# Altium schematic sheet Drive_DC_Motor.SchDoc(Drive_DC_Motor)
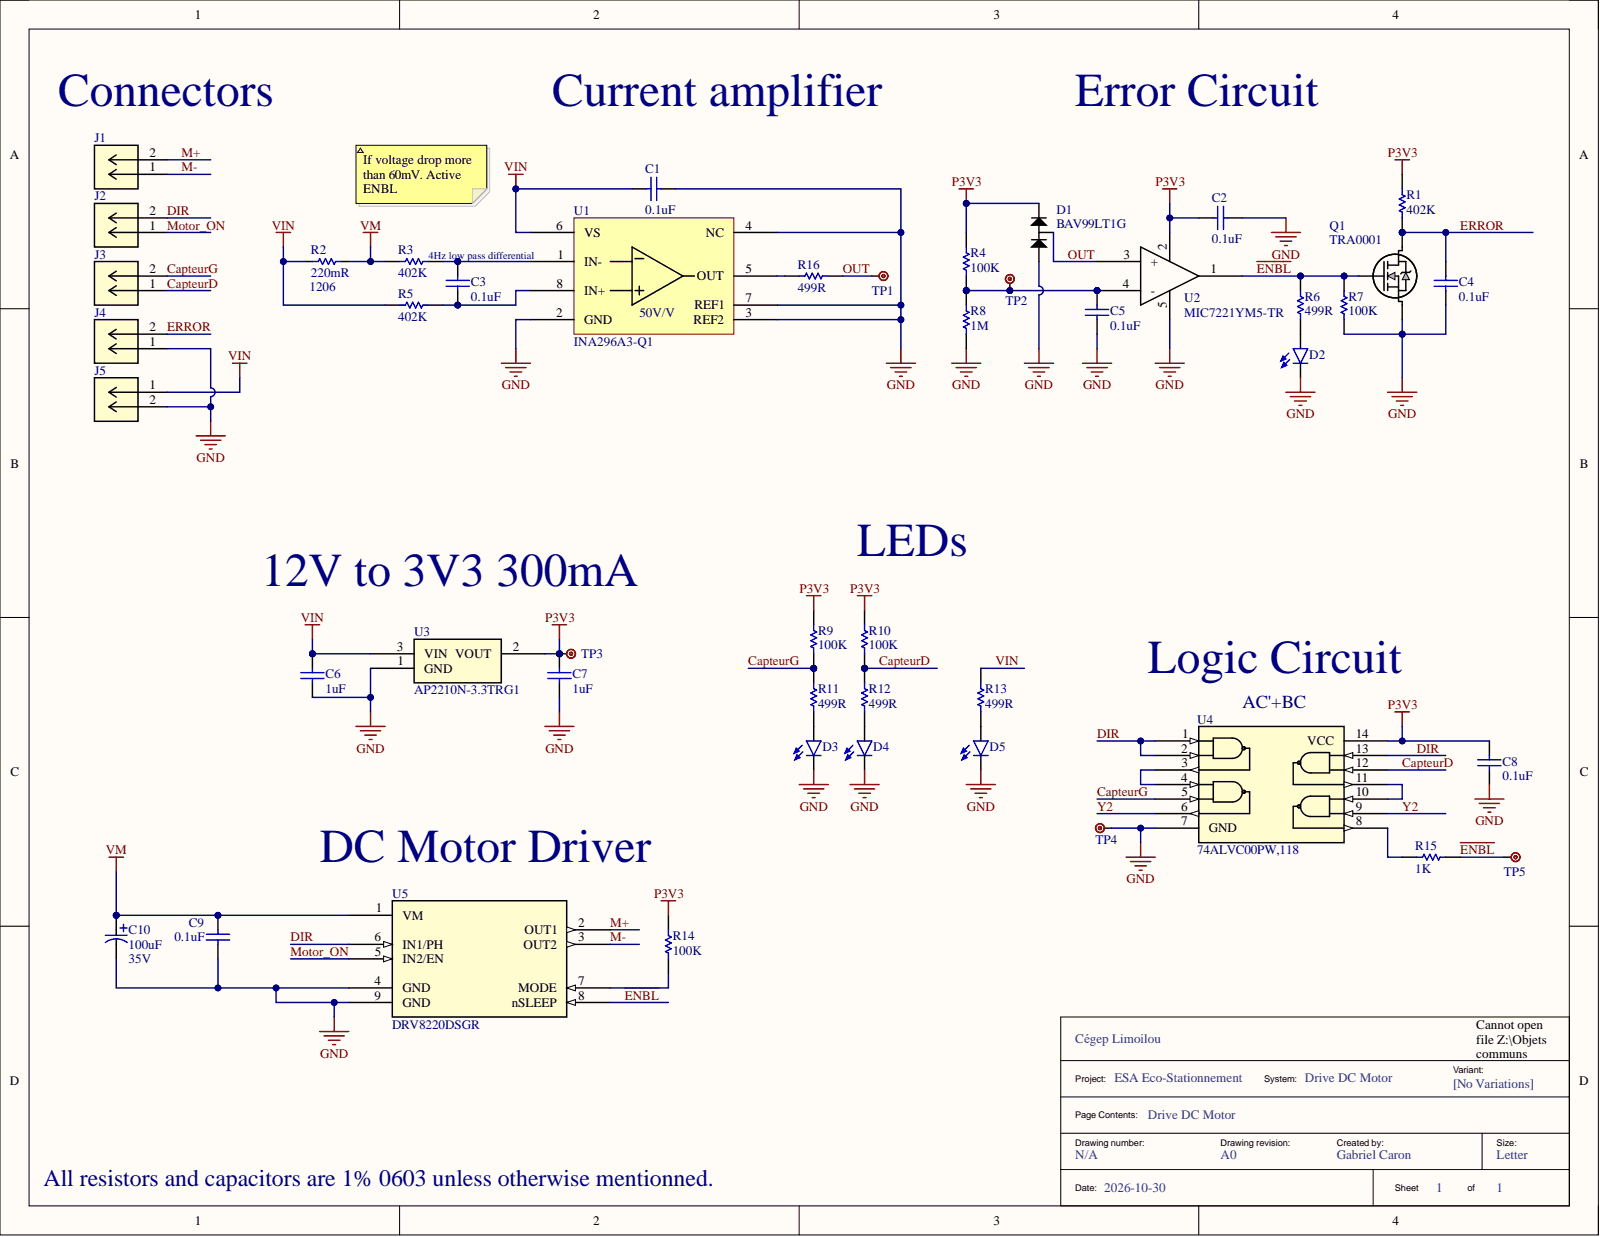
[diagram p0: Drive_DC_Motor.SchDoc]

## Parts
C1  value 0.1uF  [C0603-P]  (hidden comment: 06035C104KAT2A)
C2  value 0.1uF  [C0603-P]  (hidden comment: 06035C104KAT2A)
C3  value 0.1uF  [C0603-P]  (hidden comment: 06035C104KAT2A)
C4  value 0.1uF  [C0603-P]  (hidden comment: 06035C104KAT2A)
C5  value 0.1uF  [C0603-P]  (hidden comment: 06035C104KAT2A)
C6  value 1uF  [C0805]  (hidden comment: CC0805KKX7R9BB105)
C7  value 1uF  [C0805]  (hidden comment: CC0805KKX7R9BB105)
C8  value 0.1uF  [C0603-P]  (hidden comment: 06035C104KAT2A)
C9  value 0.1uF  [C0603-P]  (hidden comment: 06035C104KAT2A)
C10  value 100uF  Voltage: 35V  [WCAP-ASLI_6.3X7.7(DXL)]  (hidden comment: 865080545012)
D1  BAV99LT1G  [BAV99LT1G]
D2  LTST-C190KRKT  [LEDC1608X35N]  (value not drawn)
D3  LTST-C190KRKT  [LEDC1608X35N]  (value not drawn)
D4  LTST-C190KRKT  [LEDC1608X35N]  (value not drawn)
D5  MDIO0070  [LEDC1608X35N]  (value not drawn)
J1  VN02A1500000G  [CONN2_VN02A1500000G_AMP]  (value not drawn)
J2  VN02A1500000G  [CONN2_VN02A1500000G_AMP]  (value not drawn)
J3  VN02A1500000G  [CONN2_VN02A1500000G_AMP]  (value not drawn)
J4  VN02A1500000G  [CONN2_VN02A1500000G_AMP]  (value not drawn)
J5  VN02A1500000G  [CONN2_VN02A1500000G_AMP]  (value not drawn)
Q1  TRA0001  [TO-236AB_NEX]
R1  value 402K  [R0603]  (hidden comment: RC0603FR-07402KL)
R2  value 220mR  Package: 1206  [R1206]  (hidden comment: RL1206FR-070R22L)
R3  value 402K  [R0603]  (hidden comment: RC0603FR-07402KL)
R4  value 100K  [R0603]  (hidden comment: RC0603FR-07100KL)
R5  value 402K  [R0603]  (hidden comment: RC0603FR-07402KL)
R6  value 499R  [R0603]  (hidden comment: RT0603BRD07499RL)
R7  value 100K  [R0603]  (hidden comment: RC0603FR-07100KL)
R8  value 1M  [R0603]  (hidden comment: RC0603FR-071ML)
R9  value 100K  [R0603]  (hidden comment: RC0603FR-07100KL)
R10  value 100K  [R0603]  (hidden comment: RC0603FR-07100KL)
R11  value 499R  [R0603]  (hidden comment: RT0603BRD07499RL)
R12  value 499R  [R0603]  (hidden comment: RT0603BRD07499RL)
R13  value 499R  [R0603]  (hidden comment: RT0603BRD07499RL)
R14  value 100K  [R0603]  (hidden comment: RC0603FR-07100KL)
R15  value 1K  [R0603]  (hidden comment: RC0603FR-071KL)
R16  value 499R  [R0603]  (hidden comment: RT0603BRD07499RL)
TP1  5000  [TP_5000_MIN]  (value not drawn)
TP2  5000  [TP_5000_MIN]  (value not drawn)
TP3  5000  [TP_5000_MIN]  (value not drawn)
TP4  5000  [TP_5000_MIN]  (value not drawn)
TP5  5000  [TP_5000_MIN]  (value not drawn)
U1  INA296A3-Q1  [SOIC127P600X175-8N]
U2  MIC7221YM5-TR  [MIC7221YM5-TR]
U3  AP2210N-3.3TRG1  [SOT_3.3TRG1_DIO-L]
U4  74ALVC00PW,118  [TSSOP14_SOT402-1_NEX]
U5  DRV8220DSGR  [WSON8_DSG_TEX]

## Nets
GND: C1.2 C2.2 C4.1 C5.1 C6.1 C7.1 C8.1 C9.1 C10.2 D1.1 D2.C D3.C D4.C D5.C J4.1 J5.2 Q1.2 R7.1 R8.1 TP4.1 U1.2(GND) U1.3(REF2) U1.4(NC) U1.7(REF1) U2.5 U3.1 U4.7(GND) U5.4(GND) U5.9(GND)
P3V3: C2.1 C7.2 C8.2 D1.2 R1.2 R4.2 R9.2 R10.2 R14.2 TP3.1 U2.2 U3.2 U4.14(VCC)
VM: C9.2 C10.1 R2.2 R3.1 U5.1(VM)
CapteurD: J3.1 R10.1 R12.2 U4.12
CapteurG: J3.2 R9.1 R11.2 U4.5
DIR: J2.2 U4.1 U4.2 U4.13 U5.6(IN1/PH)
~{ENBL}: Q1.1 R6.2 R7.2 R15.2 TP5.1 U2.1 U5.8(nSLEEP)
ERROR: C4.2 J4.2 Q1.3 R1.1
M+: J1.2 U5.2(OUT1)
M-: J1.1 U5.3(OUT2)
Motor_ON: J2.1 U5.5(IN2/EN)
OUT: D1.3 R16.2 TP1.1 U2.3(+)
VIN: C1.1 C6.2 J5.1 R2.1 R5.1 R13.2 U1.6(VS) U3.3
Y2: U4.6 U4.9
NetC3_1: C3.1 R5.2 U1.8(IN+)
NetC3_2: C3.2 R3.2 U1.1(IN-)
NetC5_2: C5.2 R4.1 R8.2 TP2.1 U2.4(-)
NetD2_A: D2.A R6.1
NetD3_A: D3.A R11.1
NetD4_A: D4.A R12.1
NetD5_A: D5.A R13.1
NetR14_1: R14.1 U5.7(MODE)
NetR15_1: R15.1 U4.8
NetR16_1: R16.1 U1.5(OUT)
NetU4_3: U4.3 U4.4
NetU4_10: U4.10 U4.11

## Library data of the parts
C1-C5 C8 C9 = CAP0021: CAP CER 0.1UF 50V X7R 0603 10%; Manufacturer: KYOCERA AVX; ID_CEP: MCAP0021; Verif_1: RCA le 2022-02-21; Component Kind: Standard; Dielectric: X7R; Fitted: Fitted; Package: 0603; PN_Manuf: 06035C104KAT2A; Library Name: Eco-Stationnement.SchLib
C6 C7 = CAP0002: CAP CER 1UF 50V X7R 0805; Manufacturer: Yageo; ID_CEP: MCAP0002; Verif_1: JSMG; Component Kind: Standard; Cost: 0.08; Fitted: Fitted; LatestRevisionDate: 17-Jul-2002; LatestRevisionNote: Re-released for DXP Platform.; Package: 0805; PackageDescription: Radial Cap, Thru-Hole; 2 Leads; 0.3 in Pin Spacing; PN_Manuf: CC0805KKX7R9BB105; Published: 8-Jun-2000; Library Name: Eco-Stationnement.SchLib; Publisher: Altium Limited
C10 = 865080545012: CAP ALUM 100UF 20% 35V SMD; Manufacturer: Würth Elektronik; Component Kind: Standard; Fitted: Fitted; Package: 0603; PN_Manuf: 865080545012; Library Name: Eco-Stationnement.SchLib
D1 = DIO0002: Diode Array 1 Pair Series Connection Standard 100V 215mA (DC) SOT-23-3; Manufacturer: ON Semiconductor; ID_CEP: MDIO0002; Verif_1: JSMG; Component Kind: Standard; Cost: 0.18; Fitted: Fitted; Package: 3-SOT-23; PN_Manuf: BAV99LT1G; Library Name: Eco-Stationnement.SchLib
D2-D4 = LTST-C190KRKT: LED RED CLEAR CHIP SMD; Manufacturer: Lite-On Inc.; Availability: In Stock; Check_prices: https://www.snapeda.com/parts/LTST-C190KRKT/Lite-On+Inc./view-part/?ref=eda; Component Kind: Standard; ComponentLink1Description: New link; Fitted: Fitted; MF: Lite-On Inc.; MP: LTST-C190KRKT; Package: 0603 Lite-On; PN_Manuf: LTST-C190KRKT; Price: None; Purchase-URL: https://pricing.snapeda.com/search/part/LTST-C190KRKT/?ref=eda; SnapEDA_Link: https://www.snapeda.com/parts/LTST-C190KRKT/Lite-On+Inc./view-part/?ref=snap; Library Name: Eco-Stationnement.SchLib
D5 = MDIO0070: LED GREEN CLEAR SMD; Manufacturer: Lite-On Inc.; MP: LTST-C191KGKT; Availability: In Stock; Check_prices: https://www.snapeda.com/parts/LTST-C190KRKT/Lite-On+Inc./view-part/?ref=eda; Component Kind: Standard; ComponentLink1Description: New link; Fitted: Fitted; ID_CEP: DIO0070; MF: Lite-On Inc.; Package: 0603 Lite-On; PN_Manuf: LTST-C191KGKT; Price: None; Purchase-URL: https://pricing.snapeda.com/search/part/LTST-C190KRKT/?ref=eda; SnapEDA_Link: https://www.snapeda.com/parts/LTST-C190KRKT/Lite-On+Inc./view-part/?ref=snap; Library Name: Eco-Stationnement.SchLib
J1-J5 = VN02A1500000G: TERM BLK 2P SIDE ENT 2.54MM PCB; Manufacturer: Amphenol ICC; Component Kind: Standard; Fitted: Fitted; Manufacturer_Name: Amphenol ICC; Manufacturer_Part_Number: VN02A1500000G; PN_Manuf: VN02A1500000G; RefDes: RefDes; Type: Type; Copyright: Copyright (C) 2025 Ultra Librarian. All rights reserved.; Library Name: Eco-Stationnement.SchLib
Q1 = TRA0001: MOSFET N-CH 60V 300MA TO236AB; Manufacturer: Nexperia; Manufacturer_Part_Number: 2N7002,215; Verif_1: GC; Component Kind: Standard; Fitted: Fitted; ID_CEP: TRA0001; Manufacturer_Name: Nexperia; PN_Manuf: 2N7002,215; Copyright: Copyright (C) 2025 Ultra Librarian. All rights reserved.; Library Name: Eco-Stationnement.SchLib
R1 R3 R5 = RC0603FR-07402KL: RES 402K OHM 1% 1/10W 0603; Manufacturer: YAGEO; Verif_1: JSMG; Component Kind: Standard; Cost: 0.01; Fitted: Fitted; LatestRevisionDate: 17-Jul-2002; LatestRevisionNote: Re-released for DXP Platform.; Package: 0603; PackageDescription: Axial Device, Thru-Hole; 2 Leads; 0.3 in Pin Spacing; PackageReference: AXIAL-0.3; PN_Manuf: RC0603FR-07402KL; Published: 8-Jun-2000; Library Name: Eco-Stationnement.SchLib; Publisher: Altium Limited
R2 = RL1206FR-070R22L: RES 0.22 OHM 1% 1/4W 1206; Manufacturer: YAGEO; Verif_1: GC; Component Kind: Standard; Cost: 0.01; Fitted: Fitted; LatestRevisionDate: 17-Jul-2002; LatestRevisionNote: Re-released for DXP Platform.; PackageDescription: Axial Device, Thru-Hole; 2 Leads; 0.3 in Pin Spacing; PackageReference: AXIAL-0.3; PN_Manuf: RL1206FR-070R22L; Published: 8-Jun-2000; Library Name: Eco-Stationnement.SchLib; Publisher: Altium Limited
R4 R7 R9 R10 R14 = RES0003: RES SMD 100K OHM 1% 1/10W 0603; Manufacturer: YAGEO; ID_CEP: MRES0003; Verif_1: JSMG; Component Kind: Standard; Cost: 0.01; Fitted: Fitted; LatestRevisionDate: 17-Jul-2002; LatestRevisionNote: Re-released for DXP Platform.; Package: 0603; PackageDescription: Axial Device, Thru-Hole; 2 Leads; 0.3 in Pin Spacing; PackageReference: AXIAL-0.3; PN_Manuf: RC0603FR-07100KL; Published: 8-Jun-2000; Library Name: Eco-Stationnement.SchLib; Publisher: Altium Limited
R6 R11-R13 R16 = RES0015: RES SMD 499 OHM 0.1% 1/10W 0603; Manufacturer: YAGEO; ID_CEP: MRES0015; Verif_1: JSMG; Component Kind: Standard; Cost: X; Fitted: Fitted; LatestRevisionDate: 17-Jul-2002; LatestRevisionNote: Re-released for DXP Platform.; Package: 0603; PackageDescription: Axial Device, Thru-Hole; 2 Leads; 0.3 in Pin Spacing; PackageReference: AXIAL-0.3; PN_Manuf: RT0603BRD07499RL; Published: 8-Jun-2000; Library Name: Eco-Stationnement.SchLib; Publisher: Altium Limited
R8 = RES0023: RES SMD 1M OHM 1% 1/10W 0603; Manufacturer: Yageo; ID_CEP: MRES0023; Verif_1: JSMG; Component Kind: Standard; Cost: 0.01; Fitted: Fitted; LatestRevisionDate: 17-Jul-2002; LatestRevisionNote: Re-released for DXP Platform.; Package: 0603; PackageDescription: Axial Device, Thru-Hole; 2 Leads; 0.3 in Pin Spacing; PackageReference: AXIAL-0.3; PN_Manuf: RC0603FR-071ML; Published: 8-Jun-2000; Library Name: Eco-Stationnement.SchLib; Publisher: Altium Limited
R15 = RES0001: RES SMD 1K OHM 1% 1/10W 0603; Manufacturer: Yageo; ID_CEP: MRES0001; Verif_1: JSMG; Component Kind: Standard; Cost: 0.01; Fitted: Fitted; LatestRevisionDate: 17-Jul-2002; LatestRevisionNote: Re-released for DXP Platform.; Package: 0603; PackageDescription: Axial Device, Thru-Hole; 2 Leads; 0.3 in Pin Spacing; PackageReference: AXIAL-0.3; PN_Manuf: RC0603FR-071KL; Published: 8-Jun-2000; Library Name: Eco-Stationnement.SchLib; Publisher: Altium Limited
TP1-TP5 = DIV0034: PC TEST POINT MINIATURE RED 1mm; Manufacturer: Keystone Electronics; Fitted: Not Fitted; ID_CEP: MDIV0034; Verif_1: RCA le 2024-03-27; Component Kind: Standard; PN_Manuf: 5000; Library Name: Eco-Stationnement.SchLib
U1 = INA296A3-Q1: Current Sense Amplifier 1 Circuit Single-Ended 8-SOIC; Manufacturer: Texas Instruments; Component Kind: Standard; Fitted: Fitted; PN_Manuf: INA296A3-Q1; Library Name: Eco-Stationnement.SchLib
U2 = MIC7221YM5-TR: IC COMPARATOR 1 GEN PUR SOT23-5; Manufacturer: Microchip; Component Kind: Standard; Fitted: Fitted; PN_Manuf: MIC7221YM5-TR; Library Name: Eco-Stationnement.SchLib
U3 = AP2210N-3.3TRG1: IC REG LINEAR 3.3V 300MA SOT23-3; Manufacturer: Diodes Inc; Component Kind: Standard; Fitted: Fitted; Manufacturer_Name: Diodes Inc; Manufacturer_Part_Number: AP2210N-3.3TRG1; PN_Manuf: AP2210N-3.3TRG1; RefDes: RefDes; Type: Type; Copyright: Copyright (C) 2025 Ultra Librarian. All rights reserved.; Library Name: Eco-Stationnement.SchLib
U4 = 74ALVC00PW,118: NAND Gate IC 4 Channel 14-TSSOP; Manufacturer: Nexperia; Component Kind: Standard; Fitted: Fitted; Manufacturer_Name: Nexperia; Manufacturer_Part_Number: 74ALVC00PW,118; PN_Manuf: 74ALVC00PW,118; RefDes: RefDes; Type: Type; Copyright: Copyright (C) 2025 Ultra Librarian. All rights reserved.; Library Name: Eco-Stationnement.SchLib
U5 = DRV8220DSGR: 20-V, 1.76-A H-bridge motor driver with auto sleep mode 8-WSON -40 to 125; Manufacturer: Texas Instruments; Component Kind: Standard; Fitted: Fitted; Manufacturer_Name: Texas Instruments; Manufacturer_Part_Number: DRV8220DSGR; PN_Manuf: DRV8220DSGR; RefDes: RefDes; Type: Type; Copyright: Copyright (C) 2025 Ultra Librarian. All rights reserved.; Library Name: Eco-Stationnement.SchLib
